# Altium schematic sheet Sheet1.SchDoc
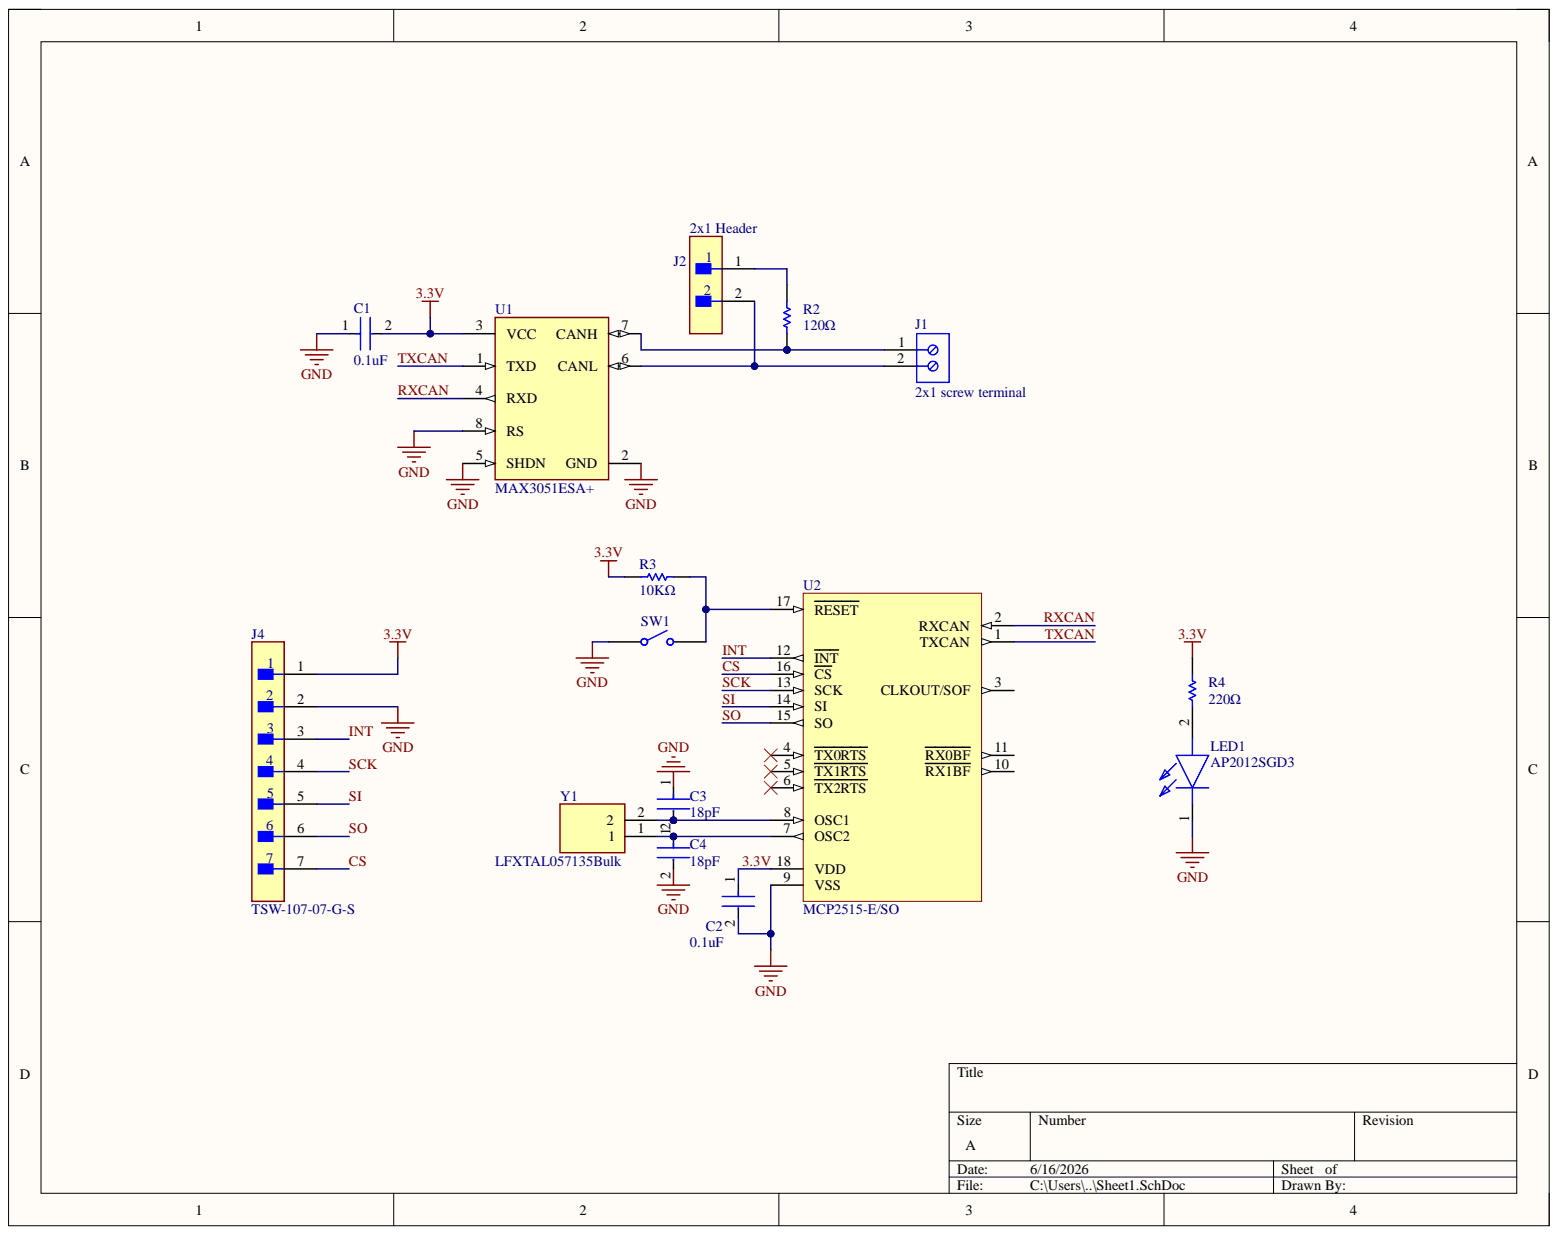
[diagram p0: Sheet1.SchDoc]

## Parts
C1  0.1uF  [FP-C0805C-DG-IPC_C]
C2  0.1uF  [FP-C0805C-DG-IPC_C]
C3  18pF  [FP-C0805C-DG-IPC_C]
C4  18pF  [FP-C0805C-DG-IPC_C]
J1  2x1 screw terminal  [TECO-282836-2_V]
J2  2x1 Header  [0.1" Header 2x1-Footprint]
J4  TSW-107-07-G-S  [FP-TSW-107-07-G-S-MFG]
LED1  AP2012SGD3  [LEDC2012X110N]
R2  120Ω  [RESC2013X50X30ML20T20]
R3  10KΩ  [RESC2013X50X30ML20T20]
R4  220Ω  [RESC2013X50X30ML20T20]
SW1  6mmx3.5mm SMD Switch  [434111050826]  (value not drawn)
U1  MAX3051ESA+  [FP-S8-4-IPC_B]
U2  MCP2515-E/SO  [SOIC-SO18_L]
Y1  LFXTAL057135Bulk  [LFXTAL057135Bulk]

## Nets
GND: C1.1 C2.2 C3.1 C4.2 J4.2 LED1.1 SW1.1 U1.2(GND) U1.5(SHDN) U1.8(RS) U2.9(VSS)
3.3V: C1.2 C2.1 J4.1 R3.1 R4.2 U1.3(VCC) U2.18(VDD)
CS: J4.7 U2.16(CS)
INT: J4.3 U2.12(INT)
RXCAN: U1.4(RXD) U2.2(RXCAN)
SCK: J4.4 U2.13(SCK)
SI: J4.5 U2.14(SI)
SO: J4.6 U2.15(SO)
TXCAN: U1.1(TXD) U2.1(TXCAN)
NetC3_2: C3.2 U2.8(OSC1) Y1.2
NetC4_1: C4.1 U2.7(OSC2) Y1.1
NetJ1_1: J1.1 R2.1 U1.7(CANH)
NetJ1_2: J1.2 J2.2 U1.6(CANL)
NetJ2_1: J2.1 R2.2
NetLED1_2: LED1.2 R4.1
NetR3_2: R3.2 SW1.2 U2.17(RESET)

Not connected: U2 3(CLKOUT/SOF) 4(TX0RTS) 5(TX1RTS) 6(TX2RTS) 10(RX1BF) 11(RX0BF)

## Library data of the parts
C1-C4 = CMP-001-00083-4: GENERIC CAPACITOR 0805 or 0603(1608); Component Kind: Standard; Library Name: Sooner Competitive Robotics
J1 = CMP-002-00073-3: 5mm pitch Connector, Terminal Block; Wire Receptacle; 2 Pos.; 16-30 AWG; 0.197 in. CL; Sid 2 pin screw terminal, 5mm pitch; Component Kind: Standard; Library Name: Sooner Competitive Robotics
J2 = CMP-002-00002-10: Board Connector, 2 Contact(s), 1 Row(s), Male, Straight, 0.1 inch and 0.079 inch Pitch, Solder Terminal, Locking, Black Insulator; Component Kind: Standard; Connector Type: BOARD CONNECTOR; Mounting Technology: Board; RoHS Compliant: Yes; Library Name: Sooner Competitive Robotics
J4 = CMP-02766-000065-1: CONN HEADER VERT 7POS 2.54MM; Manufacturer: SAMTEC; Conn Gender: Male; Conn Orientation: Straight; Number Of Contacts: 7; Automotive: No; Category: Conn; Component Kind: Standard; ComponentLink2Description: Datasheet; ComponentLink2URL: http://suddendocs.samtec.com/prints/tsw-xxx-xx-xxx-x-xx-xxx-mkt.pdf; Contact Material: Gold,Nickel; Device Class L1: Connectors; Device Class L2: Headers and Wire Housings; Device Class L3: unset; Digikey Description: CONN HEADER VERT 7POS 2.54MM; Footprint Url: http://suddendocs.samtec.com/prints/tsw-xxx-xx-x-x-xx-xxx-footprint.pdf; Height: 8.71mm; Lead Free: Yes; Library Name: Altium Content Vault; Manufacturer Part Number: TSW-107-07-G-S; Mouser Description: Conn Unshrouded Header HDR 7 POS 2.54mm Solder ST Thru-Hole Automotive Bulk; Number Of Rows: 1; Octopart: https://octopart.com/tsw-107-07-g-s-samtec-272202; Package: 0; Pitch: 2.54mm; RoHS: TRUE; Temperature: -55°C to +125°C; Temperature Range High: +125°C; Temperature Range Low: -55°C
LED1 = CMP-013-000001-2: Green LED; Manufacturer_Name: Kingbright; Component Kind: Standard; Datasheet Link: https://componentsearchengine.com//AP2012SGD3.pdf; Design Item ID: AP2012SGD3; Height: 1.1mm; Manufacturer_Part_Number: AP2012SGD3; Mouser2 Part Number: 604-AP2012SGD3; Mouser2 Price/Stock: https://www.mouser.com/Search/Refine.aspx?Keyword=604-AP2012SGD3; Source: SamacSys.SchLib; Library Name: Sooner Competitive Robotics
R2-R4 = CMP-009-00177-4: Surface Mount 0805 Resistor; Component Kind: Standard; Library Name: Sooner Competitive Robotics
SW1 = CMP-011-00013-1: Switch Tactile Button Spst-no 0.05A 12V; Component Kind: Standard; Library Name: Sooner Competitive Robotics
U1 = CMP-015-00014-1: MAX3051 Series 3.3 V 1 Mbps Low-Supply-Current CAN Transceiver - SOIC-8; Component Kind: Standard; Library Name: Sooner Competitive Robotics
U2 = CMP-044-000000-3: Stand-Alone CAN Controller With SPI Interface, 18-Pin SOIC, Extended Temperature; Code_JEDEC: MS-013; Component Kind: Standard; ComponentLink1Description: Manufacturer URL; ComponentLink1URL: http://www.microchip.com/; ComponentLink2Description: Datasheet; ComponentLink2URL: http://ww1.microchip.com/downloads/en/DeviceDoc/21801f.pdf; ComponentLink3Description: Package Specification; ComponentLink3URL: http://www.microchip.com/stellent/groups/techpub_sg/documents/packagingspec/en012702.pdf; DatasheetVersion: revF, Oct-2010; Design Item ID: CMP-0186-00035-2; PackageDescription: 18-Lead Plastic Small Outline (SO) - Wide 7.50mm Body [SOIC]; PackageReference: SOIC-SO18; PackageVersion: revBB, Aug-2009; RevisionNote: URLs added. DatasheetVersion updated.; Source: Components - 27.09.22 - 19.51.SchLib; Library Name: Sooner Competitive Robotics
Y1 = CMP-007-000001-1: Crystals 8MHz 16pF -40C 85C; Manufacturer_Name: IQD; Component Kind: Standard; Datasheet Link: https://componentsearchengine.com/Datasheets/1/LFXTAL057135Bulk.pdf; Design Item ID: LFXTAL057135Bulk; Height: 4.1mm; Manufacturer_Part_Number: LFXTAL057135Bulk; Source: SamacSys.SchLib; Library Name: Sooner Competitive Robotics
